# Altium schematic sheet Sound board.SchDoc
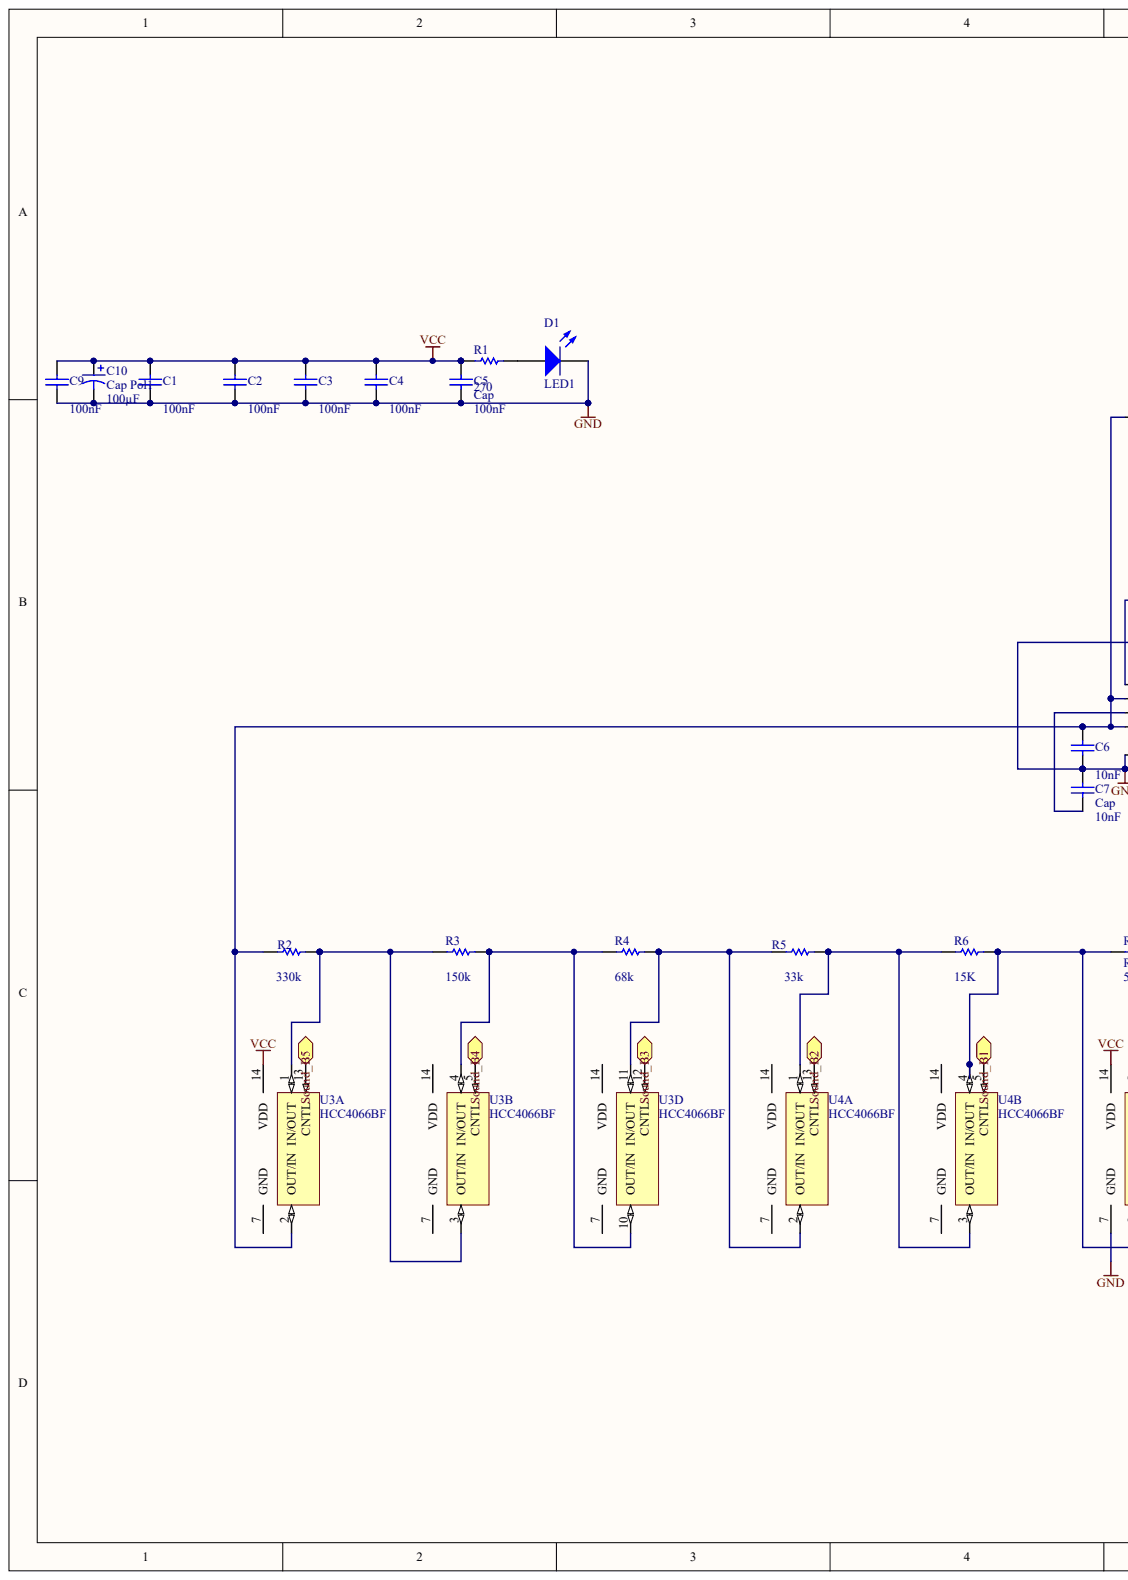
[diagram p0: Sound board.SchDoc, left]
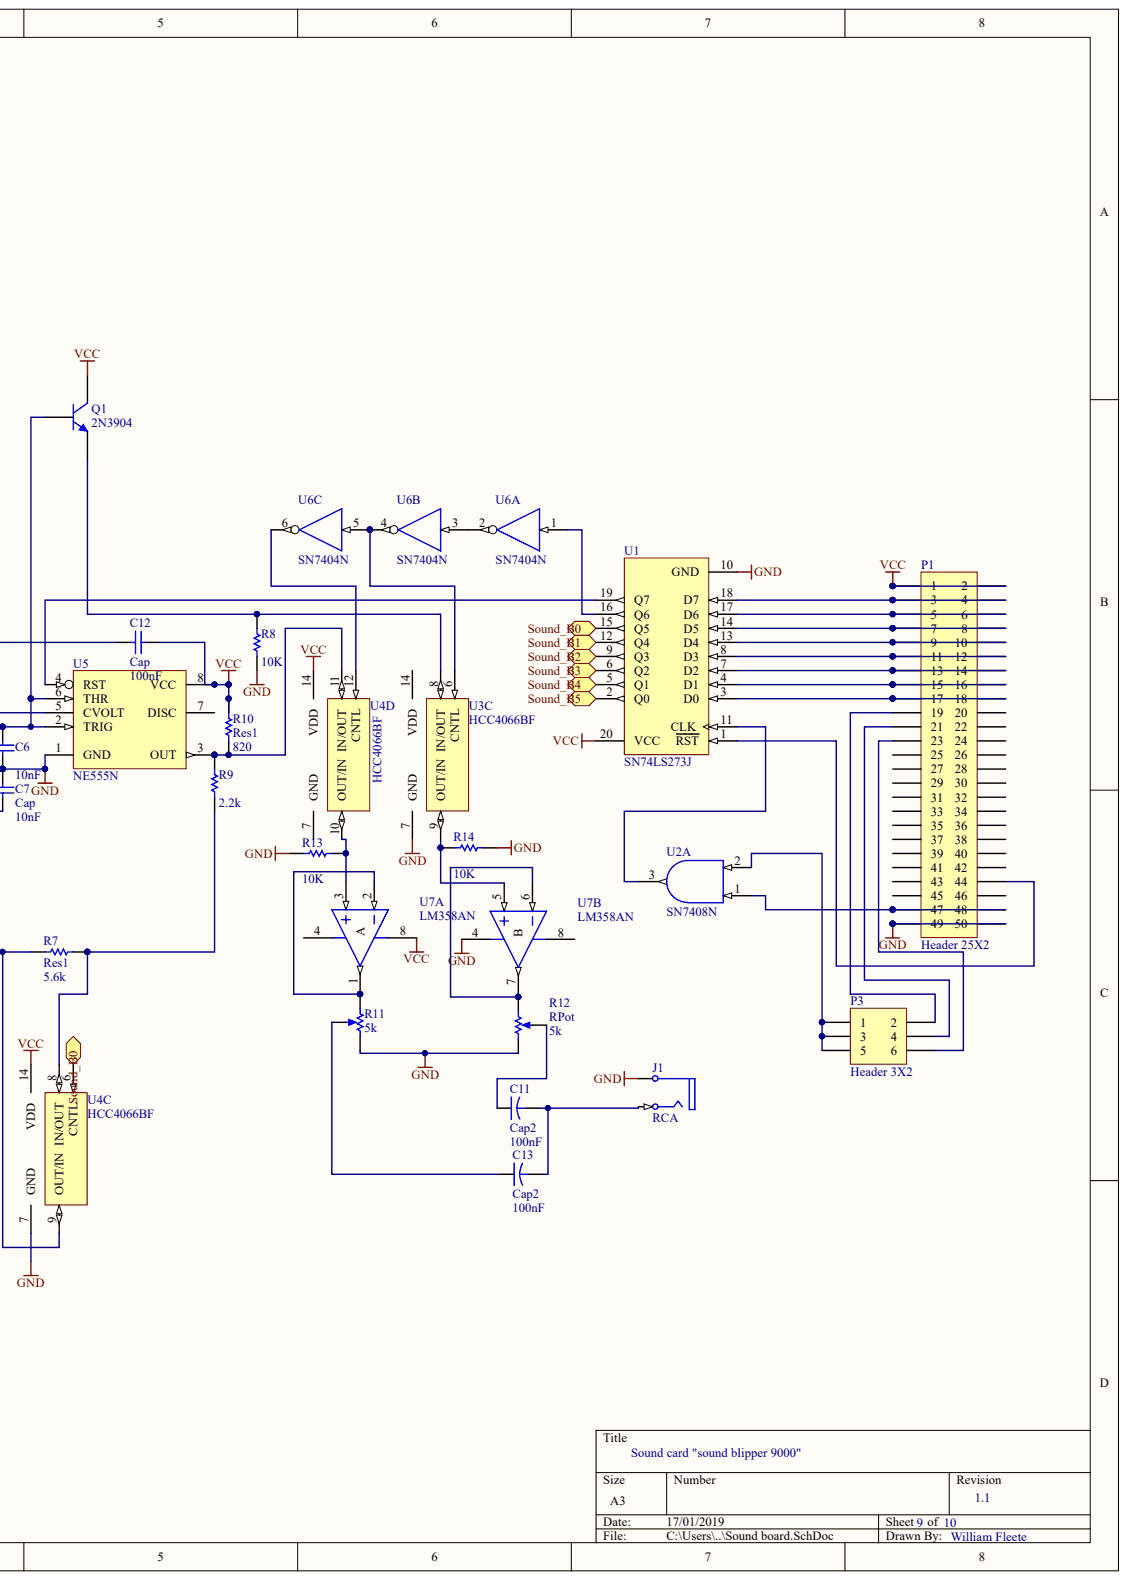
[diagram p1: Sound board.SchDoc, right]

## Parts
C1  Cap  value 100nF
C2  value 100nF  (hidden comment: Cap)
C3  value 100nF  (hidden comment: Cap)
C4  value 100nF  (hidden comment: Cap)
C5  Cap  value 100nF
C6  Cap  value 10nF
C7  Cap  value 10nF
C9  value 100nF  (hidden comment: Cap)
C10  Cap Pol1  value 100µF
C11  Cap2  value 100nF
C12  Cap  value 100nF
C13  Cap2  value 100nF
D1  LED1
J1  RCA
P1  Header 25X2
P3  Header 3X2
Q1  2N3904
R1  value 270  (hidden comment: Res1)
R2  value 330k  (hidden comment: Res1)
R3  value 150k  (hidden comment: Res1)
R4  value 68k  (hidden comment: Res1)
R5  value 33k  (hidden comment: Res1)
R6  value 15K  (hidden comment: Res1)
R7  Res1  value 5.6k
R8  value 10K  (hidden comment: Res1)
R9  Res1  value 2.2k
R10  Res1  value 820
R11  value 5k  (hidden comment: RPot)
R12  RPot  value 5k
R13  value 10K  (hidden comment: Res1)
R14  value 10K  (hidden comment: Res1)
U1  SN74LS273J
U2  SN7408N  (part A)
U3  HCC4066BF  (parts A,B,C,D)
U4  HCC4066BF  (parts A,B,C,D)
U5  NE555N
U6  SN7404N  (parts A,B,C)
U7  LM358AN  (parts A,B)

## Ports
SOUND_B0, SOUND_B1, SOUND_B2, SOUND_B3, SOUND_B4, SOUND_B5

## Nets
GND: C1.1 C2.1 C3.1 C4.1 C5.1 C6.1 C7.2 C9.1 C10.2 C12.1 D1.2 J1.1A P1.49 P1.50 R8.1 R11.2 R12.1 R13.1 R14.2 U1.10(GND) U2.7 U3.7 U4.7 U5.1(GND) U6.7 U7.4
VCC: C1.2 C2.2 C3.2 C4.2 C5.2 C9.2 C10.1 C12.2 P1.1 P1.2 Q1.3 R1.1 R10.2 U1.20(VCC) U2.14 U3.14 U4.14 U5.8(VCC) U6.14 U7.8
NetC6_2: C6.2 Q1.2 R2.2 U3.2(OUT/IN) U5.2(TRIG) U5.6(THR)
NetC7_1: C7.1 U5.5(CVOLT)
NetC11_1: C11.1 R12.3
NetC11_2: C11.2 C13.2 J1.2B
NetC13_1: C13.1 R11.3
NetD1_1: D1.1 R1.2
NetP1_3: P1.3 P1.4 U1.18(D7)
NetP1_5: P1.5 P1.6 U1.17(D6)
NetP1_7: P1.7 P1.8 U1.14(D5)
NetP1_9: P1.9 P1.10 U1.13(D4)
NetP1_11: P1.11 P1.12 U1.8(D3)
NetP1_13: P1.13 P1.14 U1.7(D2)
NetP1_15: P1.15 P1.16 U1.4(D1)
NetP1_17: P1.17 P1.18 U1.3(D0)
NetP1_19: P1.19 P3.2
NetP1_21: P1.21 P3.4
NetP1_23: P1.23 P3.6
NetP1_44: P1.44 U1.1(RST)
NetP1_47: P1.47 P1.48 U2.1
NetP3_1: P3.1 P3.3 P3.5 U2.2
NetQ1_1: Q1.1 R8.2 U3.8(IN/OUT)
NetR2_1: R2.1 R3.1 U3.1(IN/OUT) U3.3(OUT/IN)
NetR3_2: R3.2 R4.2 U3.4(IN/OUT) U3.10(OUT/IN)
NetR4_1: R4.1 R5.2 U3.11(IN/OUT) U4.2(OUT/IN)
NetR5_1: R5.1 R6.2 U4.1(IN/OUT) U4.3(OUT/IN)
NetR6_1: R6.1 R7.2 U4.4(IN/OUT) U4.9(OUT/IN)
NetR7_1: R7.1 R9.1 U4.8(IN/OUT)
NetR9_2: R9.2 R10.1 U4.11(IN/OUT) U5.3(OUT)
NetR11_1: R11.1 U7.1 U7.2
NetR12_2: R12.2 U7.6 U7.7
NetR13_2: R13.2 U4.10 U7.3
NetR14_1: R14.1 U3.9 U7.5
NetU1_2: U1.2(Q0) U3.13(CNTL) ; port SOUND_B5
NetU1_5: U1.5(Q1) U3.5(CNTL) ; port SOUND_B4
NetU1_6: U1.6(Q2) U3.12(CNTL) ; port SOUND_B3
NetU1_9: U1.9(Q3) U4.13(CNTL) ; port SOUND_B2
NetU1_11: U1.11(CLK) U2.3
NetU1_12: U1.12(Q4) U4.5(CNTL) ; port SOUND_B1
NetU1_15: U1.15(Q5) U4.6(CNTL) ; port SOUND_B0
NetU1_16: U1.16(Q6) U6.1
NetU1_19: U1.19(Q7) U5.4(RST)
NetU3_6: U3.6(CNTL) U6.4 U6.5
NetU4_12: U4.12(CNTL) U6.6
NetU6_2: U6.2 U6.3

Not connected: P1 20 22 24 25 26 27 28 29 30 31 32 33 34 35 36 37 38 39 40 41 42 43 45 46; U5 7(DISC)

## Library data of the parts
C11 C12 = (no symbol name): Value: 100nF; Publisher: Altium Limited
  C11: Published: 23-Sep-2002; PackageReference: CAPR5-4X5; PackageDescription: Capacitor; 2 Leads
  C12: Published: 8-Jun-2000; LatestRevisionDate: 17-Jul-2002; LatestRevisionNote: Re-released for DXP Platform.; PackageReference: RAD-0.3; PackageDescription: Radial Cap, Thru-Hole; 2 Leads; 0.3 in Pin Spacing
J1 = (no symbol name): PCB_Layout: Complies with manufacturer's recommendation.; DrillAndSlotSize: Corresponds to nominal value.; Published: 15-Jul-2004; LatestRevisionDate: 15-Jul-2004; LatestRevisionNote: Component Replaced.; DatasheetDocument: 7-Jul-2000; PackageDocument: 7-Jul-2000; PackageReference: 161; ComponentLink1Description: Manufacturer Link; ComponentLink1URL: http://www.mouser.com/kobiconn/; Publisher: Altium Limited
R1 = (no symbol name): Value: 270; Published: 8-Jun-2000; LatestRevisionDate: 17-Jul-2002; LatestRevisionNote: Re-released for DXP Platform.; PackageReference: AXIAL-0.3; PackageDescription: Axial Device, Thru-Hole; 2 Leads; 0.3 in Pin Spacing; Publisher: Altium Limited
R11 R12 = (no symbol name): Sim Note: Enter the Resistance value and the set point into parameter fields.; Set Position: 0.5; Published: 8-Jun-2000; LatestRevisionDate: 17-Jul-2002; LatestRevisionNote: Re-released for DXP Platform.; PackageReference: VR5; PackageDescription: Variable Resistor, Thru-Hole; 3 Leads; Publisher: Altium Limited
R13 = (no symbol name): Value: 10K; Published: 8-Jun-2000; LatestRevisionDate: 17-Jul-2002; LatestRevisionNote: Re-released for DXP Platform.; PackageReference: AXIAL-0.3; PackageDescription: Axial Device, Thru-Hole; 2 Leads; 0.3 in Pin Spacing; Publisher: Altium Limited
U1 = (no symbol name): ComponentAvailability: Obsolete; Published: 8-Jun-2000; LatestRevisionDate: 04-Mar-2005; LatestRevisionNote: Stylized 3D Model Added.; DatasheetVersion: Mar-1988; PackageVersion: Jun-1999; PackageReference: J020; PackageDescription: DIP; 20 Leads; Row Spacing 7.62 mm; Pitch 2.54 mm; ComponentLink1Description: Manufacturer Link; ComponentLink1URL: http://www.ti.com/; ComponentLink2Description: Datasheet; ComponentLink2URL: http://www-s.ti.com/sc/ds/sn74273.pdf; ComponentLink3Description: Discontinuation Notice; ComponentLink3URL: http://focus.ti.com/docs/prod/folders/print/sn74ls273.html; Publisher: Altium Limited
C1-C7 C9 C10 C13 D1 P1 P3 Q1 R2-R10 R14 U2-U7 = (no symbol name): Publisher: Altium Limited
  C1-C7 C9: Published: 8-Jun-2000; LatestRevisionDate: 17-Jul-2002; LatestRevisionNote: Re-released for DXP Platform.; PackageReference: RAD-0.3; PackageDescription: Radial Cap, Thru-Hole; 2 Leads; 0.3 in Pin Spacing
  C10: Published: 8-Jun-2000; LatestRevisionDate: 17-Jul-2002; LatestRevisionNote: Re-released for DXP Platform.; PackageReference: RB7.6-15; PackageDescription: Polarized Capacitor (Radial); 2 Leads
  C13: Published: 23-Sep-2002; PackageReference: CAPR5-4X5; PackageDescription: Capacitor; 2 Leads
  D1: Published: 8-Jun-2000; LatestRevisionDate: 08-Jul-2005; LatestRevisionNote: Mech Layer 1 removed.; PackageReference: LED-1; PackageDescription: LED; 2 Leads
  P1 P3: LatestRevisionDate: 17-Jul-2002; LatestRevisionNote: Re-released for DXP Platform.
  Q1: Published: 8-Jun-2000; LatestRevisionDate: 15-Jan-2003; LatestRevisionNote: PCB Footprint 'BCY-W3/D4.7' replaced; DatasheetVersion: 1997; PackageVersion: Sep-1998; PackageReference: TO-92A; PackageDescription: TO, Flat Index; 3 In-Line, Axial Leads; Body Dia. 4.5mm; Leads 0.51 x 0.48 mm (max); ComponentLink1Description: Manufacturer Link; ComponentLink1URL: http://www.fairchildsemi.com/; ComponentLink2Description: Datasheet; ComponentLink2URL: http://www.fairchildsemi.com/ds/2N%2F2N3904.pdf
  R2-R10 R14: Published: 8-Jun-2000; LatestRevisionDate: 17-Jul-2002; LatestRevisionNote: Re-released for DXP Platform.; PackageReference: AXIAL-0.3; PackageDescription: Axial Device, Thru-Hole; 2 Leads; 0.3 in Pin Spacing
  U2: Published: 8-Jun-2000; LatestRevisionDate: 04-Mar-2005; LatestRevisionNote: Stylized 3D Model Added.; DatasheetVersion: Mar-1988; PackageVersion: Oct-1995; PackageReference: N014; PackageDescription: DIP; 14 Leads; Row Spacing 7.62 mm; Pitch 2.54 mm; ComponentLink1Description: Manufacturer Link; ComponentLink1URL: http://www.ti.com/; ComponentLink2Description: Datasheet; ComponentLink2URL: http://www-s.ti.com/sc/ds/sn54ls08.pdf; ComponentLink3Description: Packaging Specification; ComponentLink3URL: http://focus.ti.com/lit/ml/mpdi002c/mpdi002c.pdf
  U3 U4: Published: 8-Jun-2000; LatestRevisionDate: 04-Mar-2005; LatestRevisionNote: Stylized 3D Model Added.; DatasheetVersion: Jun-1989; PackageReference: CDIP14; PackageDescription: DIP; 14 Leads; Row Spacing 7.62 mm; Pitch 2.54 mm; ComponentLink1Description: Manufacturer Link; ComponentLink1URL: http://www.st.com/
  U5: Published: 24-Aug-2001; LatestRevisionDate: 06-Jun-2005; LatestRevisionNote: Stylized 3D Model Added.; DatasheetVersion: Jul-1998; PackageReference: DIP8; PackageDescription: DIP; 8 Leads; Row Spacing 7.62 mm; Pitch 2.54 mm; Code_JEDEC: MO-001-AN; ComponentLink1Description: Manufacturer Link; ComponentLink1URL: http://www.st.com/; ComponentLink2Description: Datasheet; ComponentLink2URL: http://www.st.com/stonline/products/literature/ds/2182/ne555.pdf
  U6: Published: 8-Jun-2000; LatestRevisionDate: 04-Mar-2005; LatestRevisionNote: Stylized 3D Model Added.; DatasheetVersion: Mar-1988; PackageVersion: Oct-1995; PackageReference: N014; PackageDescription: DIP; 14 Leads; Row Spacing 7.62 mm; Pitch 2.54 mm; ComponentLink1Description: Manufacturer Link; ComponentLink1URL: http://www.ti.com/; ComponentLink2Description: Datasheet; ComponentLink2URL: http://www-s.ti.com/sc/ds/sn5404.pdf; ComponentLink3Description: Packaging Specification; ComponentLink3URL: http://focus.ti.com/lit/ml/mpdi002c/mpdi002c.pdf
  U7: Published: 24-Aug-2001; LatestRevisionDate: 06-Jun-2005; LatestRevisionNote: Stylized 3D Model Added.; DatasheetVersion: Jun-1998; PackageReference: DIP8; PackageDescription: DIP; 8 Leads; Row Spacing 7.62 mm; Pitch 2.54 mm; Code_JEDEC: MO-001-AN; ComponentLink1Description: Manufacturer Link; ComponentLink1URL: http://www.st.com/; ComponentLink2Description: Datasheet; ComponentLink2URL: http://www.st.com/stonline/products/literature/ds/2163/lm358.pdf
